# Altium schematic sheet 05_Peripheral.SchDoc
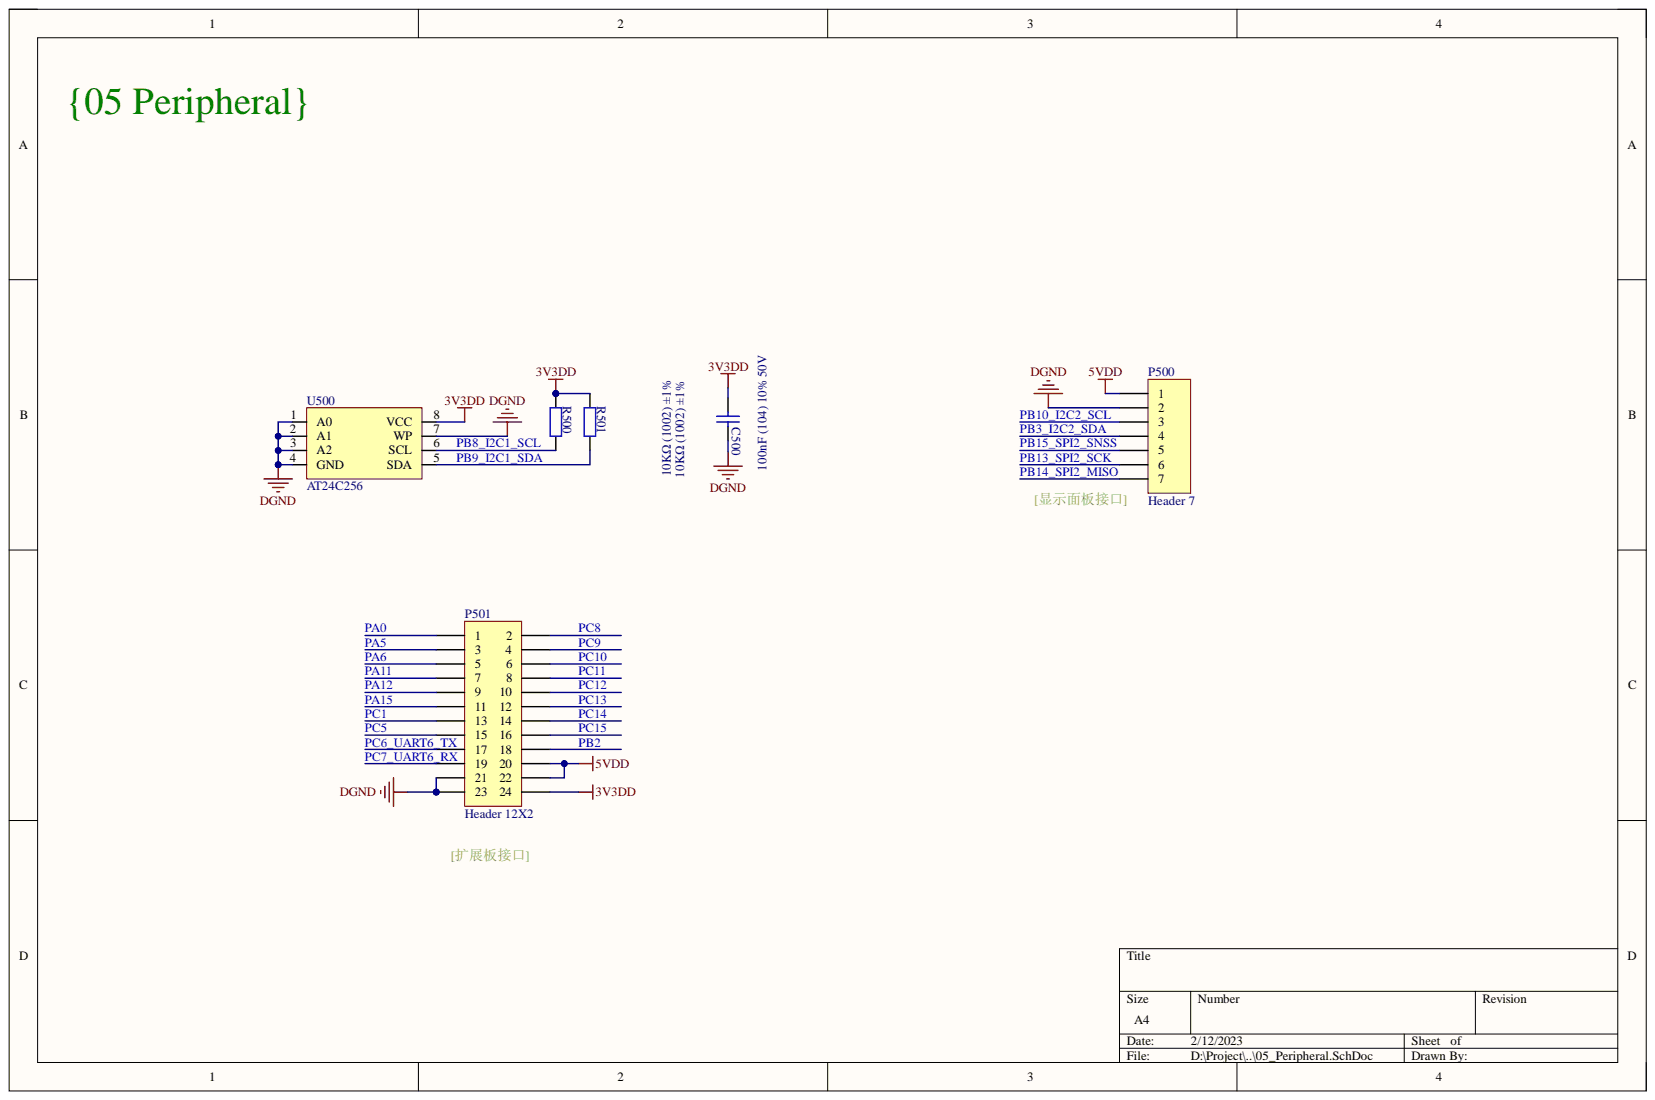
[diagram p0: 05_Peripheral.SchDoc]

## Parts
C500  100nF (104) 10% 50V  [0603_C]
P500  Header 7  [HDR1X7]
P501  Header 12X2  [HDR2X12]
R500  10KΩ (1002) ±1%  [0603_R]
R501  10KΩ (1002) ±1%  [0603_R]
U500  AT24C256  [SOIC8]

## Nets
3V3DD: C500.2 P501.24 R500.2 R501.2 U500.8(VCC)
5VDD: P500.1 P501.20 P501.22
DGND: C500.1 P500.2 P501.21 P501.23 U500.1(A0) U500.2(A1) U500.3(A2) U500.4(GND) U500.7(WP)
PA0: P501.1
PA5: P501.3
PA6: P501.5
PA11: P501.7
PA12: P501.9
PA15: P501.11
PB2: P501.18
PB3_I2C2_SDA: P500.4
PB8_I2C1_SCL: R500.1 U500.6(SCL)
PB9_I2C1_SDA: R501.1 U500.5(SDA)
PB10_I2C2_SCL: P500.3
PB13_SPI2_SCK: P500.6
PB14_SPI2_MISO: P500.7
PB15_SPI2_SNSS: P500.5
PC1: P501.13
PC5: P501.15
PC6_UART6_TX: P501.17
PC7_UART6_RX: P501.19
PC8: P501.2
PC9: P501.4
PC10: P501.6
PC11: P501.8
PC12: P501.10
PC13: P501.12
PC14: P501.14
PC15: P501.16

## Library data of the parts
C500 = 0603 100nF (104) 10% 50V: 贴片电容; Component Kind: Standard; ComponentLink1Description: 供应商链接; ComponentLink1URL: http://www.szlcsc.com/product/details_15331.html; Library Name: Miscellaneous Devices LC.IntLib; Package: 0603; Supplier: LC; SuppliersPartNumber: C14663
P500 = Header 7: Header, 7-Pin; Component Kind: Standard; LatestRevisionDate: 17-Jul-2002; LatestRevisionNote: Re-released for DXP Platform.; Library Name: Miscellaneous Connectors.IntLib; Signal Integrity: Connector; Publisher: Altium Limited
P501 = Header 12X2: Header, 12-Pin, Dual row; Component Kind: Standard; LatestRevisionDate: 17-Jul-2002; LatestRevisionNote: Re-released for DXP Platform.; Library Name: Miscellaneous Connectors.IntLib; Signal Integrity: Connector; Publisher: Altium Limited
R500 R501 = 0603 10KΩ (1002) 1%: 贴片电阻; Component Kind: Standard; ComponentLink1Description: 供应商链接; ComponentLink1URL: http://www.szlcsc.com/product/details_26547.html; Library Name: Miscellaneous Devices LC.IntLib; Package: 0603; Supplier: LC; SuppliersPartNumber: C25804
U500 = AT24C256: Component Kind: Standard; Library Name: Schlibv2.SchLib
